# Altium schematic sheet BLE.SchDoc(BLE)
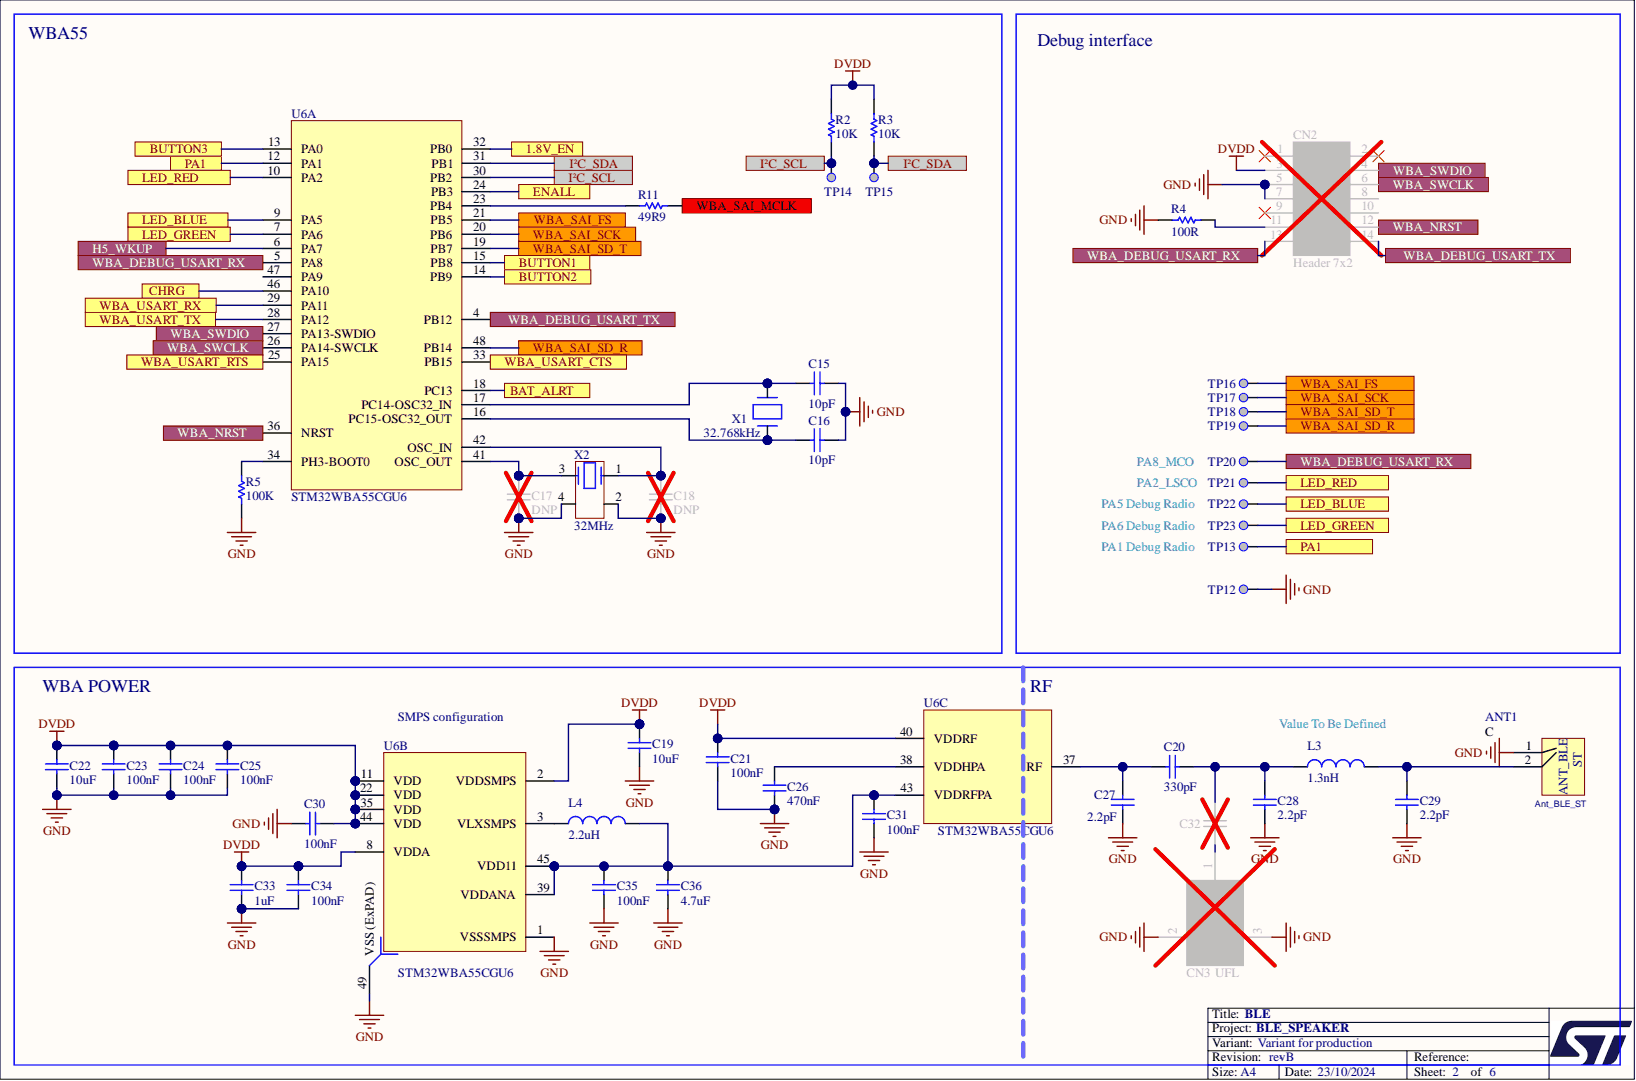
[diagram p0: BLE.SchDoc]

## Parts
ANT1  Ant_BLE_ST  [Ant_BLE_ST]
C15  value 10pF  [C0402]  (hidden comment: Capacitor)
C16  value 10pF  [C0402]  (hidden comment: Capacitor)
C17  value DNP  [C0402]  (hidden comment: Capacitor)
C18  value DNP  [C0402]  (hidden comment: Capacitor)
C19  value 10uF  [C0402]  (hidden comment: Capacitor)
C20  value 330pF  [C0402]  (hidden comment: Capacitor)
C21  value 100nF  [C0201_H0P3]  (hidden comment: Capacitor)
C22  value 10uF  [C0402]  (hidden comment: Capacitor)
C23  value 100nF  [C0201_H0P3]  (hidden comment: Capacitor)
C24  value 100nF  [C0201_H0P3]  (hidden comment: Capacitor)
C25  value 100nF  [C0201_H0P3]  (hidden comment: Capacitor)
C26  value 470nF  [C0402]  (hidden comment: Capacitor)
C27  value 2.2pF  [C0402]  (hidden comment: Capacitor)
C28  value 2.2pF  [C0402]  (hidden comment: Capacitor)
C29  value 2.2pF  [C0402]  (hidden comment: Capacitor)
C30  value 100nF  [C0201_H0P3]  (hidden comment: Capacitor)
C31  value 100nF  [C0201_H0P3]  (hidden comment: Capacitor)
C32  Capacitor  [C0402]  (value not drawn)
C33  value 1uF  [C0402]  (hidden comment: Capacitor)
C34  value 100nF  [C0201_H0P3]  (hidden comment: Capacitor)
C35  value 100nF  [C0201_H0P3]  (hidden comment: Capacitor)
C36  value 4.7uF  [C0402]  (hidden comment: Capacitor)
CN2  Header 7x2  [CON_STDC14_Receiver_SMALL]
CN3  value UFL  [CON_HRS_U.FL-R-SMT-1]  (hidden comment: U.FL-R-SMT-1)
L3  value 1.3nH  [C0402]  (hidden comment: Inductor)
L4  value 2.2uH  [IND_2520_TOKO_DFE252012P]  (hidden comment: Inductor)
R2  value 10K  [R0402]  (hidden comment: Resistor)
R3  value 10K  [R0402]  (hidden comment: Resistor)
R4  value 100R  [R0402]  (hidden comment: Resistor)
R5  value 100K  [R0402]  (hidden comment: Resistor)
R11  value 49R9  [R0402]  (hidden comment: Resistor)
TP12  Test Point  [TP_SMD_1MM]  (value not drawn)  (no BOM)
TP13  Test Point  [TP_SMD_1MM]  (value not drawn)  (no BOM)
TP14  Test Point  [TP_SMD_1MM]  (value not drawn)  (no BOM)
TP15  Test Point  [TP_SMD_1MM]  (value not drawn)  (no BOM)
TP16  Test Point  [TP_SMD_1MM]  (value not drawn)  (no BOM)
TP17  Test Point  [TP_SMD_1MM]  (value not drawn)  (no BOM)
TP18  Test Point  [TP_SMD_1MM]  (value not drawn)  (no BOM)
TP19  Test Point  [TP_SMD_1MM]  (value not drawn)  (no BOM)
TP20  Test Point  [TP_SMD_1MM]  (value not drawn)  (no BOM)
TP21  Test Point  [TP_SMD_1MM]  (value not drawn)  (no BOM)
TP22  Test Point  [TP_SMD_1MM]  (value not drawn)  (no BOM)
TP23  Test Point  [TP_SMD_1MM]  (value not drawn)  (no BOM)
U6  STM32WBA55CGU6  [ICC_UFQFPN48-EPAD_7X7_H0P55_P050]  (parts A,B,C)
X1  value 32.768kHz  [CRY_NX1610SE_2P]  (hidden comment: XTAL_2_PINS)
X2  value 32MHz  [CRY_NX2016SA_4P]  (hidden comment: XTAL_4_PINS)

## Ports
1.8V_EN, BAT_ALRT, BUTTON1, BUTTON2, BUTTON3, CHRG, ENALL, H5_WKUP, I²C_SCL, I²C_SDA, LED_BLUE, LED_GREEN, LED_RED, PA1, WBA_DEBUG_USART_RX, WBA_DEBUG_USART_TX, WBA_NRST, WBA_SAI_FS, WBA_SAI_MCLK, WBA_SAI_SCK, WBA_SAI_SD_R, WBA_SAI_SD_T, WBA_SWCLK, WBA_SWDIO, WBA_USART_CTS, WBA_USART_RTS, WBA_USART_RX, WBA_USART_TX

## Nets
DVDD: C19.2 C21.1 C22.2 C23.1 C24.1 C25.1 C30.2 C33.2 C34.1 CN2.3 R2.1 R3.2 U6.2(VDDSMPS) U6.8(VDDA) U6.11(VDD) U6.22(VDD) U6.35(VDD) U6.40(VDDRF) U6.44(VDD)
GND: ANT1.1 C15.2 C16.2 C17.1 C18.1 C19.1 C21.2 C22.1 C23.2 C24.2 C25.2 C26.1 C27.1 C28.1 C29.1 C30.1 C31.2 C33.1 C34.2 C35.2 C36.1 CN2.5 CN2.7 CN3.2 CN3.3 R4.1 R5.1 TP12.1 U6.1(VSSSMPS) U6.49(VSS_(ExPAD)) X2.2 X2.4
1.8V_EN: U6.32(PB0) ; port 1.8V_EN
BAT_ALRT: U6.18(PC13) ; port BAT_ALRT
BUTTON1: U6.15(PB8) ; port BUTTON1
BUTTON2: U6.14(PB9) ; port BUTTON2
BUTTON3: U6.13(PA0) ; port BUTTON3
CHRG: U6.46(PA10) ; port CHRG
ENALL: U6.24(PB3) ; port ENALL
H5_WKUP: U6.6(PA7) ; port H5_WKUP
I²C_SCL: R2.2 TP14.1 U6.30(PB2) ; port I²C_SCL
I²C_SDA: R3.1 TP15.1 U6.31(PB1) ; port I²C_SDA
LED_BLUE: TP22.1 U6.9(PA5) ; port LED_BLUE
LED_GREEN: TP23.1 U6.7(PA6) ; port LED_GREEN
LED_RED: TP21.1 U6.10(PA2) ; port LED_RED
PA1: TP13.1 U6.12(PA1) ; port PA1
WBA_DEBUG_USART_RX: CN2.13 TP20.1 U6.5(PA8) ; port WBA_DEBUG_USART_RX
WBA_DEBUG_USART_TX: CN2.14 U6.4(PB12) ; port WBA_DEBUG_USART_TX
WBA_NRST: CN2.12 U6.36(NRST) ; port WBA_NRST
WBA_SAI_FS: TP16.1 U6.21(PB5) ; port WBA_SAI_FS
WBA_SAI_MCLK: R11.1 ; port WBA_SAI_MCLK
WBA_SAI_SCK: TP17.1 U6.20(PB6) ; port WBA_SAI_SCK
WBA_SAI_SD_R: TP19.1 U6.48(PB14) ; port WBA_SAI_SD_R
WBA_SAI_SD_T: TP18.1 U6.19(PB7) ; port WBA_SAI_SD_T
WBA_SWCLK: CN2.6 U6.26(PA14-SWCLK) ; port WBA_SWCLK
WBA_SWDIO: CN2.4 U6.27(PA13-SWDIO) ; port WBA_SWDIO
WBA_USART_CTS: U6.33(PB15) ; port WBA_USART_CTS
WBA_USART_RTS: U6.25(PA15) ; port WBA_USART_RTS
WBA_USART_RX: U6.29(PA11) ; port WBA_USART_RX
WBA_USART_TX: U6.28(PA12) ; port WBA_USART_TX
NetANT1_2: ANT1.2 C29.2 L3.2
NetC15_1: C15.1 U6.17(PC14-OSC32_IN) X1.2
NetC16_1: C16.1 U6.16(PC15-OSC32_OUT) X1.1
NetC17_2: C17.2 U6.41(OSC_OUT) X2.3
NetC18_2: C18.2 U6.42(OSC_IN) X2.1
NetC20_1: C20.1 C27.2 U6.37(RF)
NetC20_2: C20.2 C28.2 C32.2 L3.1
NetC26_2: C26.2 U6.38(VDDHPA)
NetC31_1: C31.1 C35.1 C36.2 L4.2 U6.39(VDDANA) U6.43(VDDRFPA) U6.45(VDD11)
NetC32_1: C32.1 CN3.1
NetCN2_11: CN2.11 R4.2
NetL4_1: L4.1 U6.3(VLXSMPS)
NetR5_2: R5.2 U6.34(PH3-BOOT0)
NetR11_2: R11.2 U6.23(PB4)

Not connected: CN2 1 2 8 9 10; U6 47(PA9)

## Library data of the parts
ANT1 = MCD-04043: ST printed PCB Antenna for BLE miniboard and module; Part_Preference: Recommended; Part_Type: Misc; Database Table Name: MCD_PARTS$; ID: 6159; ShowFields: YES; Component Kind: Standard; ComponentLink1URL: http://tunmcdsvn.tun.st.com/Component_Documentation_Library/Trunk/Misc/ANT_BLE; Internal_Part_Number: MCD-04043; Library Name: MCD_PARTS_database.DbLib; Manufacturer: N/A; Manufacturer_Part_Number: N/A; Order_Code_Digikey: N/A; Order_Code_Farnell: N/A; Order_Code_RS: N/A; Status: New; Value: Ant_BLE_ST
C15 C16 = MCD-00015: Capacitor, MLCC, 10pF, 50V, NPO, +/-5%, 0402, T=0.5mm; Manufacturer: YAGEO; Manufacturer_Part_Number: CC0402JRNPO9BN100; Part_Preference: Recommended; Component_Country_Of_Origin: China; Database Table Name: MCD_PARTS$; ID: 2465; Order_Code_RS: 3019160RL; ShowFields: YES; Component Kind: Standard; ComponentLink1URL: http://tunmcdsvn.tun.st.com/Component_Documentation_Library/Trunk/Capacitor/YAGEO_NP0_Series; Internal_Part_Number: MCD-00015; Library Name: MCD_PARTS_database.DbLib; Order_Code_Digikey: 311-1014-1-ND; Order_Code_Farnell: 3019160RL; Package: 0402; Part_Type: Capacitor; Status: Released
C17 C18 = MCD-01602: Capacitor, MLCC, 3.9pF, 50V, NPO, +/-0.1pF, 0402, T=0.5mm; Manufacturer: YAGEO; Manufacturer_Part_Number: CC0402BRNPO9BN3R9; Part_Preference: Recommended; Component_Country_Of_Origin: China; Database Table Name: MCD_PARTS$; ID: 3702; ShowFields: YES; Component Kind: Standard; ComponentLink1URL: http://tunmcdsvn.tun.st.com/Component_Documentation_Library/Trunk/Capacitor/YAGEO_NP0_Series; Internal_Part_Number: MCD-01602; Library Name: MCD_PARTS_database.DbLib; Order_Code_Digikey: 311-1622-1-ND; Order_Code_Farnell: N/A; Order_Code_RS: N/A; Package: 0402; Part_Type: Capacitor; Status: Released
C19 C22 = MCD-03140: Capacitor, MLCC, 10uF, 6.3V, X5R, +/-20%, 0402, T=0.5mm; Manufacturer: MURATA; Manufacturer_Part_Number: GRM155R60J106ME05D; Part_Preference: Recommended; Component_Country_Of_Origin: China, Japan; Database Table Name: MCD_PARTS$; ID: 5256; ShowFields: YES; Component Kind: Standard; ComponentLink1URL: http://tunmcdsvn.tun.st.com/Component_Documentation_Library/Trunk/Capacitor/MURATA_GRM_Series; Internal_Part_Number: MCD-03140; Library Name: MCD_PARTS_database.DbLib; Order_Code_Digikey: 490-GRM155R60J106ME05DTR-ND; Order_Code_Farnell: 3784428; Order_Code_RS: N/A; Package: 0402; Part_Type: Capacitor; Status: Released
C20 C32 = MCD-01579: Capacitor, MLCC, 330pF, 50V, NPO, +/-5%, 0402, T=0.5mm; Manufacturer: YAGEO; Manufacturer_Part_Number: CC0402JRNPO9BN331; Part_Preference: Recommended; Component_Country_Of_Origin: China; Database Table Name: MCD_PARTS$; ID: 3679; ShowFields: YES; Component Kind: Standard; ComponentLink1URL: http://tunmcdsvn.tun.st.com/Component_Documentation_Library/Trunk/Capacitor/YAGEO_NP0_Series; Internal_Part_Number: MCD-01579; Library Name: MCD_PARTS_database.DbLib; Order_Code_Digikey: 311-1667-1-ND; Order_Code_Farnell: N/A; Order_Code_RS: N/A; Package: 0402; Part_Type: Capacitor; Status: Released
  C32: Value: 330pF
C21 C23-C25 C30 C31 C34 C35 = MCD-00697: Capacitor, MLCC, 100nF,10V, X5R, +/-10%, 0201, T=0.3mm; Manufacturer: TDK; Manufacturer_Part_Number: C0603X5R1A104K030BC; Part_Preference: Recommended; Component_Country_Of_Origin: Unknown; Database Table Name: MCD_PARTS$; ID: 3046; ShowFields: YES; Component Kind: Standard; ComponentLink1URL: http://tunmcdsvn.tun.st.com/Component_Documentation_Library/Trunk/Capacitor/TDK_MCC_Series; Internal_Part_Number: MCD-00697; Library Name: MCD_PARTS_database.DbLib; Order_Code_Digikey: 445-7318-1-ND; Order_Code_Farnell: 2524957; Order_Code_RS: N/A; Package: 0201; Part_Type: Capacitor; Status: Released
C26 = MCD-03075: Capacitor, MLCC, 470nF, 25V, X5R, +/-10%, 0402, T=0.5mm; Manufacturer: YAGEO; Manufacturer_Part_Number: CC0402KRX5R8BB474; Part_Preference: Recommended; Component_Country_Of_Origin: China, Taiwan; Database Table Name: MCD_PARTS$; ID: 5191; ShowFields: YES; Component Kind: Standard; ComponentLink1URL: http://tunmcdsvn.tun.st.com/Component_Documentation_Library/Trunk/Capacitor/YAGEO_X5R_Series/; Internal_Part_Number: MCD-03075; Library Name: MCD_PARTS_database.DbLib; Order_Code_Digikey: 13-CC0402KRX5R8BB474TR-ND; Order_Code_Farnell: 3871700; Order_Code_RS: N/A; Package: 0402; Part_Type: Capacitor; Status: Checked
C27-C29 = MCD-01773: Capacitor, MLCC, 2.2pF, 50V, NPO, +/-0.1pF, 0402, T=0.5mm; Manufacturer: YAGEO; Manufacturer_Part_Number: CC0402BRNPO9BN2R2; Part_Preference: Recommended; Component_Country_Of_Origin: China; Database Table Name: MCD_PARTS$; ID: 3873; ShowFields: YES; Component Kind: Standard; ComponentLink1URL: http://tunmcdsvn.tun.st.com/Component_Documentation_Library/Trunk/Capacitor/YAGEO_NP0_Series; Internal_Part_Number: MCD-01773; Library Name: MCD_PARTS_database.DbLib; Order_Code_Digikey: 311-1618-1-ND; Order_Code_Farnell: N/A; Order_Code_RS: N/A; Package: 0402; Part_Type: Capacitor; Status: Released
C33 = MCD-01722: Capacitor, MLCC, 1uF, 10V, X5R, +/-10%, 0402, T=0.5mm; Manufacturer: YAGEO; Manufacturer_Part_Number: CC0402KRX5R6BB105; Part_Preference: Recommended; Component_Country_Of_Origin: China; Database Table Name: MCD_PARTS$; ID: 3822; ShowFields: YES; Component Kind: Standard; ComponentLink1URL: http://tunmcdsvn.tun.st.com/Component_Documentation_Library/Trunk/Capacitor/YAGEO_X5R_Series; Internal_Part_Number: MCD-01722; Library Name: MCD_PARTS_database.DbLib; Order_Code_Digikey: 311-1439-1-ND; Order_Code_Farnell: 1458896; Order_Code_RS: N/A; Package: 0402; Part_Type: Capacitor; Status: Released
C36 = MCD-01356: Capacitor, MLCC, 4.7uF, 6V3, X5R, +/-20%, 0402, T=0.5mm; Manufacturer: MURATA; Manufacturer_Part_Number: GRM155R60J475ME47; Part_Preference: Recommended; Component_Country_Of_Origin: Japan°°; Database Table Name: MCD_PARTS$; ID: 3456; Order_Code_RS: 814-5230; ShowFields: YES; Component Kind: Standard; ComponentLink1URL: http://tunmcdsvn.tun.st.com/Component_Documentation_Library/Trunk/Capacitor/MURATA_GRM_Series; Internal_Part_Number: MCD-01356; Library Name: MCD_PARTS_database.DbLib; Order_Code_Digikey: 490-5915-1-ND; Order_Code_Farnell: 2666407; Package: 0402; Part_Type: Capacitor; Status: Released
CN2 = MCD-00639: Header, 7x2, 1.27mm, VR, SMD, T=6.12mm; Manufacturer: SAMTEC; Manufacturer_Part_Number: FTSH-107-01-L-DV-K; Part_Preference: Recommended; Part_Type: Connector; Partial_Alternate_Internal_Part_Number: MCD-03872; Component_Country_Of_Origin: China, Costa Rica, Malaysia, USA, Vietnam; Database Table Name: MCD_PARTS$; ID: 3107; Order_Code_RS: 179-8765; ShowFields: YES; Component Kind: Standard; ComponentLink1URL: http://tunmcdsvn.tun.st.com/Component_Documentation_Library/Trunk/Connector/SAMTEC_FTSH_Series/; Internal_Part_Number: MCD-00639; Library Name: MCD_PARTS_database.DbLib; Order_Code_Digikey: SAM9449-ND; Order_Code_Farnell: 2856443; Status: Released; Value: Header 7x2
CN3 = MCD-01583: Connector_RF, 0Hz to 6GHz, 50R, VR, SMD, T= 1.25mm; BMS_Part_Number: 9187005; Manufacturer: HIROSE; Part_Preference: Recommended; Component_Country_Of_Origin: Japan, Malaysia; Database Table Name: MCD_PARTS$; ID: 3683; Order_Code_RS: 557-981; ShowFields: YES; Component Kind: Standard; ComponentLink1URL: http://tunmcdsvn.tun.st.com/Component_Documentation_Library/Trunk/Connector/HIROSE_U.FL_Series/; Internal_Part_Number: MCD-01583; Library Name: MCD_PARTS_database.DbLib; Manufacturer_Part_Number: U.FL-R-SMT-1; Order_Code_Digikey: N/A; Order_Code_Farnell: 1688077; Part_Type: Connector; Status: Released
L3 = MCD-02997: Inductor, 1.3nH, +/-0.3nH, 1A, 70mR, 0402, T=0.5mm; Manufacturer: MURATA; Manufacturer_Part_Number: LQG15HS1N3S02; Part_Preference: Recommended; Component_Country_Of_Origin: Japan°; Database Table Name: MCD_PARTS$; ID: 5113; ShowFields: YES; Component Kind: Standard; ComponentLink1URL: http://tunmcdsvn.tun.st.com/Component_Documentation_Library/Trunk/Inductor/MURATA_LQG15HS1N3S02; Internal_Part_Number: MCD-02997; Library Name: MCD_PARTS_database.DbLib; Order_Code_Digikey: 490-6568-2-ND; Order_Code_Farnell: 3351583; Order_Code_RS: N/A; Package: 0402; Part_Type: Inductor; Status: Checked
L4 = MCD-00161: Inductor, 2.2uH, +/-20%, 2,2A, 84mR, 1008, T=1.2mm; Manufacturer: TOKO; Manufacturer_Part_Number: DFE252012P-2R2M=P2; Part_Preference: Recommended; Component_Country_Of_Origin: Japan°°; Database Table Name: MCD_PARTS$; ID: 2711; Order_Code_RS: 167-0606; ShowFields: YES; Component Kind: Standard; ComponentLink1URL: http://tunmcdsvn.tun.st.com/Component_Documentation_Library/Trunk/Inductor/TOKO_DFE252012P_Series; Internal_Part_Number: MCD-00161; Library Name: MCD_PARTS_database.DbLib; Order_Code_Digikey: 490-10649-1-ND; Order_Code_Farnell: 2530074; Package: 1008; Part_Type: Inductor; Status: Checked
R2 R3 = MCD-00053: Resistor, 10K, 0402, +/-1%, 1/16W; BMS_Part_Number: 9131590; Manufacturer: YAGEO; Manufacturer_Part_Number: RC0402FR-0710KL; Part_Preference: Recommended; Component_Country_Of_Origin: China; Database Table Name: MCD_PARTS$; ID: 2539; Order_Code_RS: 9239359; ShowFields: YES; Component Kind: Standard; ComponentLink1URL: http://tunmcdsvn.tun.st.com/Component_Documentation_Library/Trunk/Resistor/YAGEO_RC_L_Series; Internal_Part_Number: MCD-00053; Library Name: MCD_PARTS_database.DbLib; Order_Code_Digikey: 311-10.0KLRCT-ND; Order_Code_Farnell: 9239359; Package: 0402; Part_Type: Resistor; Status: Released
R4 = MCD-00119: Resistor, 100R, 0402, +/-1%, 1/16W; Manufacturer: YAGEO; Manufacturer_Part_Number: RC0402FR-07100RL; Part_Preference: Not recommended; Component_Country_Of_Origin: China; Database Table Name: MCD_PARTS$; ID: 2748; ShowFields: YES; Component Kind: Standard; ComponentLink1URL: http://tunmcdsvn.tun.st.com/Component_Documentation_Library/Trunk/Resistor/YAGEO_RC_L_Series; Internal_Part_Number: MCD-00119; Library Name: MCD_PARTS_database.DbLib; Order_Code_Digikey: 311-100LRCT-ND; Order_Code_Farnell: 9239111; Order_Code_RS: N/A; Package: 0402; Part_Type: Resistor; Status: Released
R5 = MCD-00059: Resistor, 100K, 0402, +/-1%, 1/16W; Manufacturer: YAGEO; Manufacturer_Part_Number: RC0402FR-07100KL; Part_Preference: Recommended; Partial_Alternate_Internal_Part_Number: MCD-03301; Component_Country_Of_Origin: China; Database Table Name: MCD_PARTS$; ID: 2533; Order_Code_RS: 2421891; ShowFields: YES; Component Kind: Standard; ComponentLink1URL: http://tunmcdsvn.tun.st.com/Component_Documentation_Library/Trunk/Resistor/YAGEO_RC_L_Series; Internal_Part_Number: MCD-00059; Library Name: MCD_PARTS_database.DbLib; Order_Code_Digikey: 311-100KLRTR-ND; Order_Code_Farnell: 2421891; Package: 0402; Part_Type: Resistor; Status: Released
R11 = MCD-00565: Resistor, 49R9, 0402, +/-1%, 1/16W; Manufacturer: YAGEO; Manufacturer_Part_Number: RC0402FR-0749R9L; Part_Preference: Recommended; Component_Country_Of_Origin: China; Database Table Name: MCD_PARTS$; ID: 3178; ShowFields: YES; Component Kind: Standard; ComponentLink1URL: http://tunmcdsvn.tun.st.com/Component_Documentation_Library/Trunk/Resistor/YAGEO_RC_L_Series; Internal_Part_Number: MCD-00565; Library Name: MCD_PARTS_database.DbLib; Order_Code_Digikey: 311-49.9LRCT-ND; Order_Code_Farnell: 1799218; Order_Code_RS: N/A; Package: 0402; Part_Type: Resistor; Status: Released
TP12-TP23 = MCD-00074: Test Point 1mm; Part_Preference: Recommended; Part_Type: Misc; Component_Country_Of_Origin: Unknown; Database Table Name: MCD_PARTS$; ID: 2518; ShowFields: YES; ComponentLink1URL: http://tunmcdsvn.tun.st.com/Component_Documentation_Library/Trunk/Misc/; Internal_Part_Number: MCD-00074; Library Name: MCD_PARTS_database.DbLib; Manufacturer: N/A; Manufacturer_HQ_COO: Unknown; Manufacturer_Part_Number: N/A; Order_Code_Digikey: N/A; Order_Code_Farnell: N/A; Order_Code_RS: N/A; Status: Released; Value: Test Point 1mm
U6 = MCD-02743: MCU,ARM Cortex M33,1MB Flash, 128KB SRAM, Crypto, RF 2.4GHz, BLE, 802.15.4; Manufacturer: STMICROELECTRONICS; Part_Preference: Recommended; Part_Type: IC; Component_Country_Of_Origin: Unknown; Database Table Name: MCD_PARTS$; ID: 4855; ShowFields: YES; Component Kind: Standard; ComponentLink1URL: http://tunmcdsvn.tun.st.com/Component_Documentation_Library/Trunk/IC/STMICROELECTRONICS_STM32WBA55CGU6; Internal_Part_Number: MCD-02743; Library Name: MCD_PARTS_database.DbLib; Manufacturer_Part_Number: STM32WBA55CGU6; Order_Code_Digikey: N/A; Order_Code_Farnell: N/A; Order_Code_RS: N/A; Package: UFQFPN48 7X7 0.55; Status: Released; Value: STM32WBA55CGU6
X1 = MCD-02291: Crystal, 32.768kHz, 9pF, +/-20ppm, 70KR, 1.6x1mm; Manufacturer: NDK; Manufacturer_Part_Number: NX1610SE-32.768KHZ-EXS00A-MU01499; Part_Preference: Recommended; Part_Type: Crystal_Osc; Component_Country_Of_Origin: Unknown; Database Table Name: MCD_PARTS$; ID: 4391; ShowFields: YES; Component Kind: Standard; ComponentLink1URL: http://tunmcdsvn.tun.st.com/Component_Documentation_Library/Trunk/Crystal_Oscillator/NDK_NX1610SE_Series; Internal_Part_Number: MCD-02291; Library Name: MCD_PARTS_database.DbLib; Order_Code_Digikey: N/A; Order_Code_Farnell: N/A; Order_Code_RS: N/A; Package: 1.6x1mm; Status: Released
X2 = MCD-01446: Crystal, 32MHz, 8pF, +/-10ppm, 60R, 2x1.6mm; BMS_Part_Number: 9157042; Manufacturer: NDK; Manufacturer_Part_Number: NX2016SA-32MHZ-EXS00A-CS06654; Part_Preference: Recommended; Part_Type: Crystal_Osc; Component_Country_Of_Origin: Unknown; Database Table Name: MCD_PARTS$; ID: 3546; ShowFields: YES; Component Kind: Standard; ComponentLink1URL: http://tunmcdsvn.tun.st.com/Component_Documentation_Library/Trunk/Crystal_Oscillator/NDK_NX2016SA_Series; Internal_Part_Number: MCD-01446; Library Name: MCD_PARTS_database.DbLib; Order_Code_Digikey: 644-1373; Order_Code_Farnell: N/A; Order_Code_RS: N/A; Package: 2x1.6mm; Status: Checked
